# Altium schematic sheet KitArmM0.SchDoc
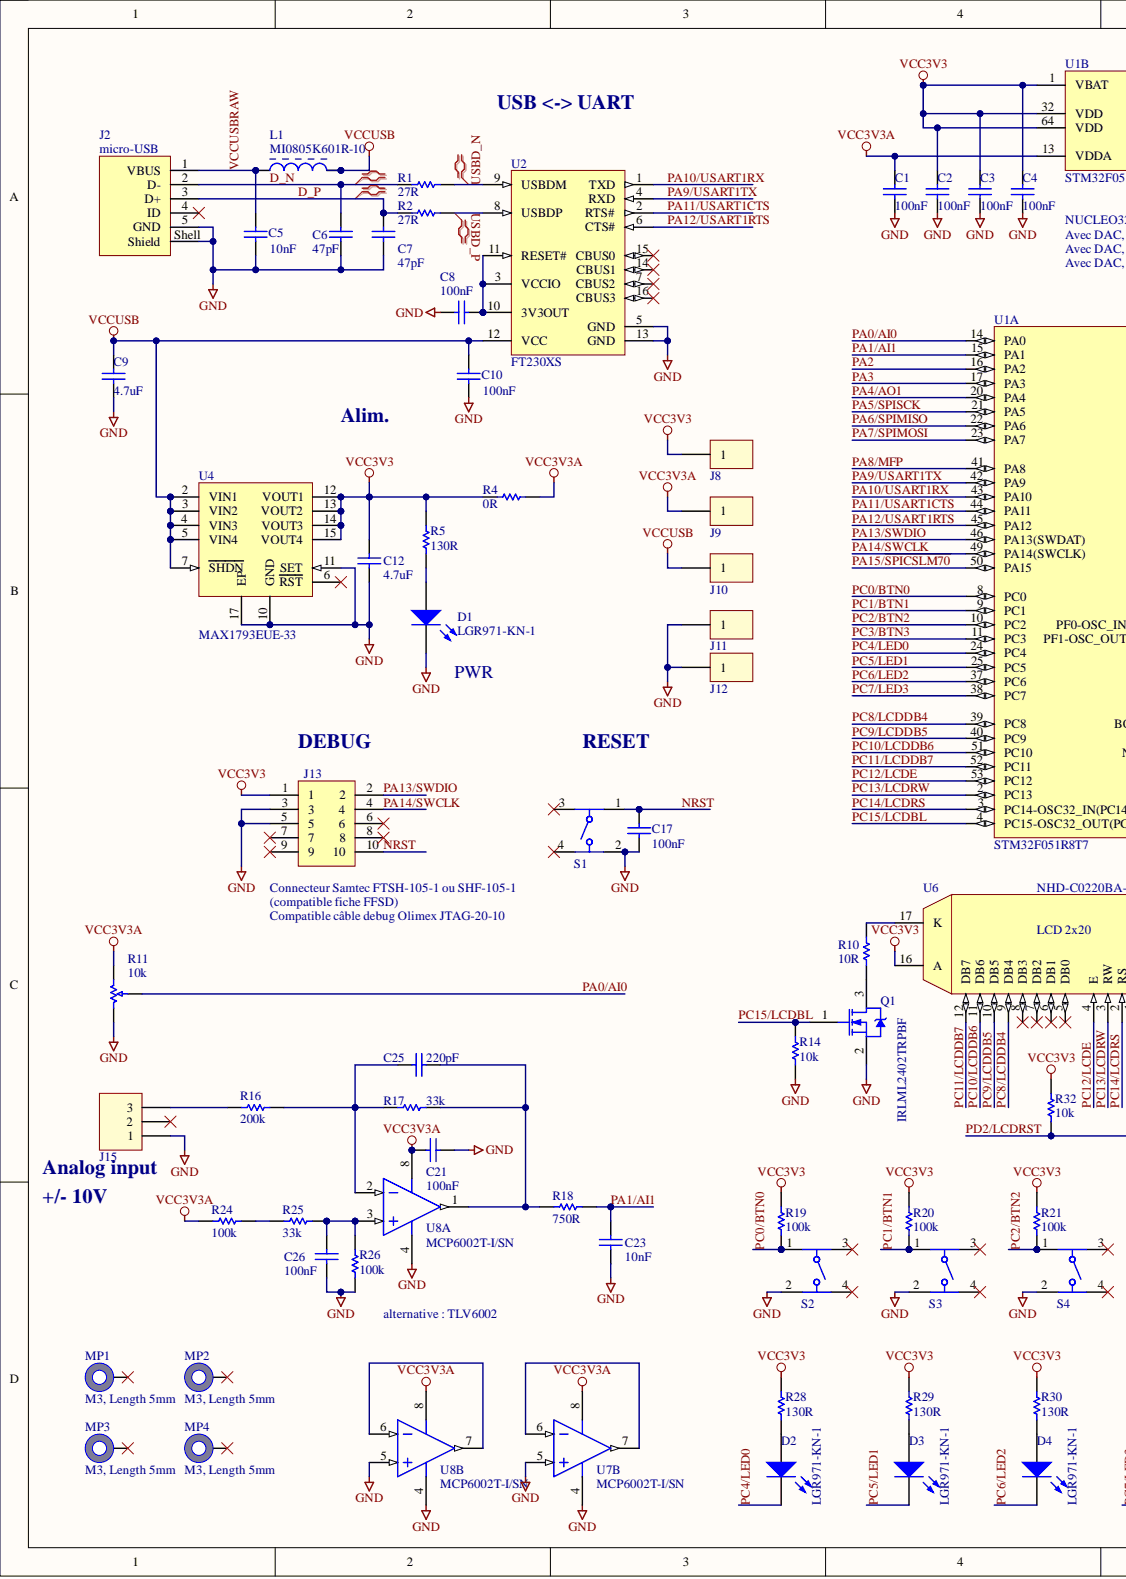
[diagram p0: KitArmM0.SchDoc, left]
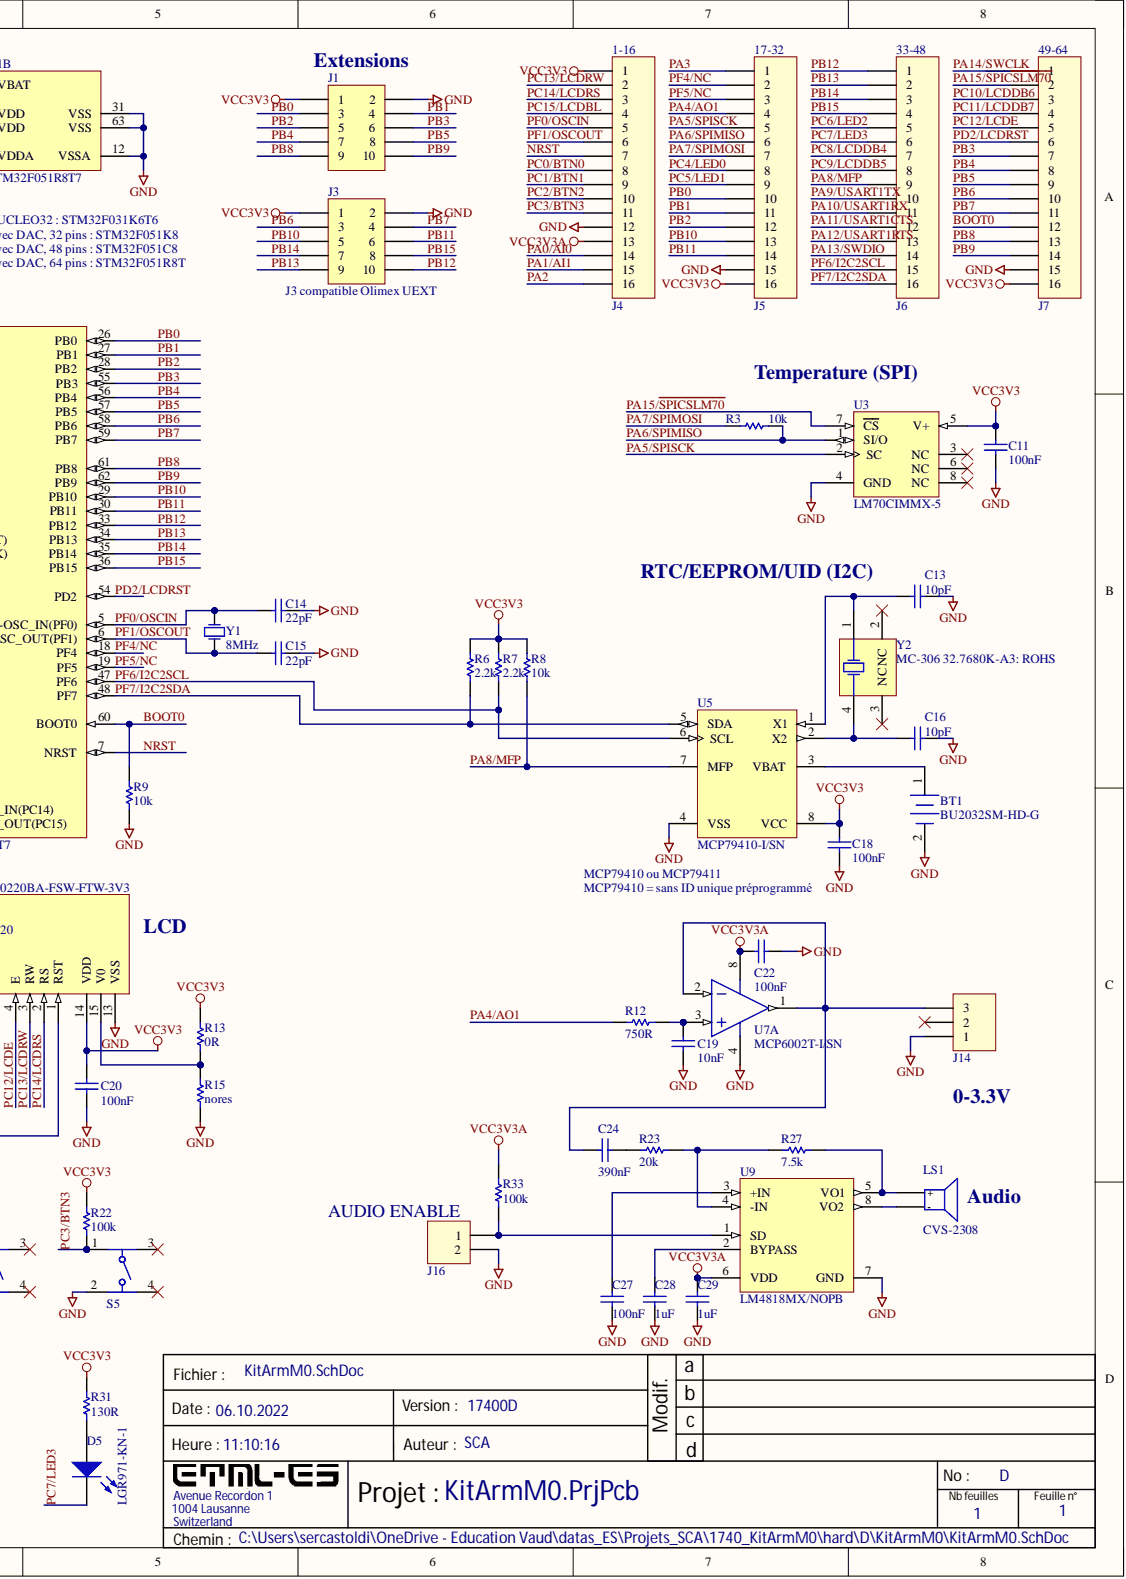
[diagram p1: KitArmM0.SchDoc, right]

## Parts
BT1  BU2032SM-HD-G  [BU2032SM-HD-G]
C1  value 100nF  [CAPC0805(2012)145_N]  (hidden comment: CAP 100nF 10V 0805(2012))
C2  value 100nF  [CAPC0805(2012)145_N]  (hidden comment: CAP 100nF 10V 0805(2012))
C3  value 100nF  [CAPC0805(2012)145_N]  (hidden comment: CAP 100nF 10V 0805(2012))
C4  value 100nF  [CAPC0805(2012)145_N]  (hidden comment: CAP 100nF 10V 0805(2012))
C5  value 10nF  [CAPC0805(2012)145_N]  (hidden comment: CAP 10nF 10V 0805(2012))
C6  value 47pF  [CAPC0805(2012)145_N]  (hidden comment: CAP 47pF 10V 0805(2012))
C7  value 47pF  [CAPC0805(2012)145_N]  (hidden comment: CAP 47pF 10V 0805(2012))
C8  value 100nF  [CAPC0805(2012)145_N]  (hidden comment: CAP 100nF 10V 0805(2012))
C9  value 4.7uF  [CAPC0805(2012)145_N]  (hidden comment: CAP 4.7uF 10V 0805(2012))
C10  value 100nF  [CAPC0805(2012)145_N]  (hidden comment: CAP 100nF 10V 0805(2012))
C11  value 100nF  [CAPC0805(2012)145_N]  (hidden comment: CAP 100nF 10V 0805(2012))
C12  value 4.7uF  [CAPC0805(2012)145_N]  (hidden comment: CAP 4.7uF 10V 0805(2012))
C13  value 10pF  [CAPC0805(2012)145_N]  (hidden comment: CAP 10pF 10V 0805(2012))
C14  value 22pF  [CAPC0805(2012)145_N]  (hidden comment: CAP 22pF 10V 0805(2012))
C15  value 22pF  [CAPC0805(2012)145_N]  (hidden comment: CAP 22pF 10V 0805(2012))
C16  value 10pF  [CAPC0805(2012)145_N]  (hidden comment: CAP 10pF 10V 0805(2012))
C17  value 100nF  [CAPC0805(2012)145_N]  (hidden comment: CAP 100nF 10V 0805(2012))
C18  value 100nF  [CAPC0805(2012)145_N]  (hidden comment: CAP 100nF 10V 0805(2012))
C19  value 10nF  [CAPC0805(2012)145_N]  (hidden comment: CAP 10nF 10V 0805(2012))
C20  value 100nF  [CAPC0805(2012)145_N]  (hidden comment: CAP 100nF 10V 0805(2012))
C21  value 100nF  [CAPC0805(2012)145_N]  (hidden comment: CAP 100nF 10V 0805(2012))
C22  value 100nF  [CAPC0805(2012)145_N]  (hidden comment: CAP 100nF 10V 0805(2012))
C23  value 10nF  [CAPC0805(2012)145_N]  (hidden comment: CAP 10nF 10V 0805(2012))
C24  value 390nF  [CAPC0805(2012)145_N]  (hidden comment: CAP 390nF 16V 0805(2012))
C25  value 220pF  [CAPC0805(2012)145_N]  (hidden comment: CAP 220pF 10V 0805(2012))
C26  value 100nF  [CAPC0805(2012)145_N]  (hidden comment: CAP 100nF 10V 0805(2012))
C27  value 100nF  [CAPC0805(2012)145_N]  (hidden comment: CAP 100nF 10V 0805(2012))
C28  value 1uF  [CAPC0805(2012)145_N]  (hidden comment: CAP 1uF 10V 0805(2012))
C29  value 1uF  [CAPC0805(2012)145_N]  (hidden comment: CAP 1uF 10V 0805(2012))
D1  LGR971-KN-1  [LED_0805]
D2  LGR971-KN-1  [LED_0805]
D3  LGR971-KN-1  [LED_0805]
D4  LGR971-KN-1  [LED_0805]
D5  LGR971-KN-1  [LED_0805]
J1  61201021621  [61201021621]  (value not drawn)
J2  value micro-USB  [629105150521]  (hidden comment: 629105150521)
J3  61201021621  [61201021621]  (value not drawn)
J4  61301611121  [61301611121]  (value not drawn)
J5  61301611121  [61301611121]  (value not drawn)
J6  61301611121  [61301611121]  (value not drawn)
J7  Rated Current: 3 A  [61301611121]  (hidden comment: 61301611121)
J8  61300111121  [61300111121]  (value not drawn)
J9  61300111121  [61300111121]  (value not drawn)
J10  61300111121  [61300111121]  (value not drawn)
J11  61300111121  [61300111121]  (value not drawn)
J12  61300111121  [61300111121]  (value not drawn)
J13  SHF-105-01-X-D-TH  [SHF-105-01-X-D-TH]  (value not drawn)
J14  61300311121  [61300311121]  (value not drawn)
J15  61300311121  [61300311121]  (value not drawn)
J16  61300211121  [61300211121]  (value not drawn)
L1  MI0805K601R-10  [LIRD-MI0805K601R-10-2_V]
LS1  CVS-2308  [CVS-2308]
MP1  M3, Length 5mm  [9774050360]
MP2  M3, Length 5mm  Length: 5mm  [9774050360]
MP3  M3, Length 5mm  [9774050360]
MP4  M3, Length 5mm  Length: 5mm  [9774050360]
Q1  IRLML2402TRPBF  [INTR-IRLML2402TRPBF-3_V]
R1  value 27R  [RESC0805(2012)_N]  (hidden comment: 27R 1% 0805(2012))
R2  value 27R  [RESC0805(2012)_N]  (hidden comment: 27R 1% 0805(2012))
R3  value 10k  [RESC0805(2012)_N]  (hidden comment: 10k 1% 0805(2012))
R4  value 0R  [RESC0805(2012)_N]  (hidden comment: 0R 1% 0805(2012))
R5  value 130R  [RESC0805(2012)_N]  (hidden comment: 130R 1% 0805(2012))
R6  value 2.2k  [RESC0805(2012)_N]  (hidden comment: 2.2k 1% 0805(2012))
R7  value 2.2k  [RESC0805(2012)_N]  (hidden comment: 2.2k 1% 0805(2012))
R8  value 10k  [RESC0805(2012)_N]  (hidden comment: 10k 1% 0805(2012))
R9  value 10k  [RESC0805(2012)_N]  (hidden comment: 10k 1% 0805(2012))
R10  value 10R  [RESC0805(2012)_N]  (hidden comment: 10R 1% 0805(2012))
R11  10k  [POT_PTV09A-2]
R12  value 750R  [RESC0805(2012)_N]  (hidden comment: 750R 1% 0805(2012))
R13  value 0R  [RESC0805(2012)_N]  (hidden comment: 0R 1% 0805(2012))
R14  value 10k  [RESC0805(2012)_N]  (hidden comment: 10k 1% 0805(2012))
R15  value nores  [RESC0805(2012)_N]  (hidden comment: nores 0805(2012))
R16  value 200k  [RESC0805(2012)_N]  (hidden comment: 200k 1% 0805(2012))
R17  value 33k  [RESC0805(2012)_N]  (hidden comment: 33k 1% 0805(2012))
R18  value 750R  [RESC0805(2012)_N]  (hidden comment: 750R 1% 0805(2012))
R19  value 100k  [RESC0805(2012)_N]  (hidden comment: 100k 1% 0805(2012))
R20  value 100k  [RESC0805(2012)_N]  (hidden comment: 100k 1% 0805(2012))
R21  value 100k  [RESC0805(2012)_N]  (hidden comment: 100k 1% 0805(2012))
R22  value 100k  [RESC0805(2012)_N]  (hidden comment: 100k 1% 0805(2012))
R23  value 20k  [RESC0805(2012)_N]  (hidden comment: 20k 1% 0805(2012))
R24  value 100k  [RESC0805(2012)_N]  (hidden comment: 100k 1% 0805(2012))
R25  value 33k  [RESC0805(2012)_N]  (hidden comment: 33k 1% 0805(2012))
R26  value 100k  [RESC0805(2012)_N]  (hidden comment: 100k 1% 0805(2012))
R27  value 7.5k  [RESC0805(2012)_N]  (hidden comment: 7.5k 1% 0805(2012))
R28  value 130R  [RESC0805(2012)_N]  (hidden comment: 130R 1% 0805(2012))
R29  value 130R  [RESC0805(2012)_N]  (hidden comment: 130R 1% 0805(2012))
R30  value 130R  [RESC0805(2012)_N]  (hidden comment: 130R 1% 0805(2012))
R31  value 130R  [RESC0805(2012)_N]  (hidden comment: 130R 1% 0805(2012))
R32  value 10k  [RESC0805(2012)_N]  (hidden comment: 10k 1% 0805(2012))
R33  value 100k  [RESC0805(2012)_N]  (hidden comment: 100k 1% 0805(2012))
S1  430182043816  [4301x20438x6_6x6x4.3]  (value not drawn)
S2  430182043816  [4301x20438x6_6x6x4.3]  (value not drawn)
S3  430182043816  [4301x20438x6_6x6x4.3]  (value not drawn)
S4  430182043816  [4301x20438x6_6x6x4.3]  (value not drawn)
S5  430182043816  [4301x20438x6_6x6x4.3]  (value not drawn)
U1  STM32F051R8T7  [STM-LQFP64_M]  (parts A,B)
U2  FT230XS  [FTDI-SSOP-16_L]
U3  LM70CIMMX-5  [MUA08A_L]
U4  MAX1793EUE-33  [TSOP65P640X110_HS-17N]
U5  MCP79410-I/SN  [SOIC-SN8_N]
U6  NHD-C0220BA-FSW-FTW-3V3  [NHD-C0220BA-FSW-FTW-3V3]
U7  MCP6002T-I/SN  [D0008A_M]  (parts A,B)
U8  MCP6002T-I/SN  [D0008A_M]  (parts A,B)
U9  LM4818MX/NOPB  [M08A_M]
Y1  Frequency: 8MHz  [HC-49/US-SM]  (hidden comment: ATS080BSM-1)
Y2  MC-306 32.7680K-A3: ROHS  Package Reference: MC-306  [EPSON-MC-306_V]

## Nets
GND: BT1.2 C1.1 C2.1 C3.1 C4.1 C5.1 C6.1 C7.1 C8.2 C9.1 C10.1 C11.1 C12.1 C13.1 C14.1 C15.1 C16.1 C17.1 C18.1 C19.2 C20.2 C21.1 C22.1 C23.2 C26.2 C27.2 C28.2 C29.2 D1.1 J1.2 J2.5(GND) J2.Shell(Shield) J3.2 J4.12 J5.15 J7.15 J11.1 J12.1 J13.3 J13.5 J14.1 J15.1 J16.2 Q1.2 R9.1 R11.1 R14.1 R15.1 R26.2 S1.2 S2.2 S3.2 S4.2 S5.2 U1.12(VSSA) U1.31(VSS) U1.63(VSS) U2.5(GND) U2.13(GND) U3.4(GND) U4.10(GND) U4.11(SET) U4.17(EP) U5.4(VSS) U6.13(VSS) U7.4 U7.5 U8.4 U8.5 U9.7(GND)
VCC3V3: C2.2 C3.2 C4.2 C11.2 C12.2 C18.2 C20.1 J1.1 J3.1 J4.1 J5.16 J7.16 J8.1 J13.1 R4.1 R5.2 R6.2 R7.2 R8.2 R13.2 R19.2 R20.2 R21.2 R22.2 R28.2 R29.2 R30.2 R31.2 R32.2 U1.1(VBAT) U1.32(VDD) U1.64(VDD) U3.5(V+) U4.12(VOUT1) U4.13(VOUT2) U4.14(VOUT3) U4.15(VOUT4) U5.8(VCC) U6.14(VDD) U6.16(A)
VCC3V3A: C1.2 C21.2 C22.2 C29.1 J4.13 J9.1 R4.2 R11.3 R24.2 R33.2 U1.13(VDDA) U7.8 U8.8 U9.6(VDD)
VCCUSB: C9.2 C10.2 J10.1 L1.2 U2.12(VCC) U4.2(VIN1) U4.3(VIN2) U4.4(VIN3) U4.5(VIN4) U4.7(SHDN)
BOOT0: J7.12 R9.2 U1.60(BOOT0)
D_N: C6.2 J2.2(D-) R1.1
D_P: C7.2 J2.3(D+) R2.1
NRST: C17.2 J4.7 J13.10 S1.1 U1.7(NRST)
PA0/AI0: J4.14 R11.2 U1.14(PA0)
PA1/AI1: C23.1 J4.15 R18.1 U1.15(PA1)
PA2: J4.16 U1.16(PA2)
PA3: J5.1 U1.17(PA3)
PA4/AO1: J5.4 R12.2 U1.20(PA4)
PA5/SPISCK: J5.5 U1.21(PA5) U3.2(SC)
PA6/SPIMISO: J5.6 R3.2 U1.22(PA6) U3.1(SI/O)
PA7/SPIMOSI: J5.7 R3.1 U1.23(PA7)
PA8/MFP: J6.9 R8.1 U1.41(PA8) U5.7(MFP)
PA9/USART1TX: J6.10 U1.42(PA9) U2.4(RXD)
PA10/USART1RX: J6.11 U1.43(PA10) U2.1(TXD)
PA11/USART1CTS: J6.12 U1.44(PA11) U2.2(RTS#)
PA12/USART1RTS: J6.13 U1.45(PA12) U2.6(CTS#)
PA13/SWDIO: J6.14 J13.2 U1.46(PA13(SWDAT))
PA14/SWCLK: J7.1 J13.4 U1.49(PA14(SWCLK))
PA15/~{SPICSLM70}: J7.2 U1.50(PA15) U3.7(CS)
PB0: J1.3 J5.10 U1.26(PB0)
PB1: J1.4 J5.11 U1.27(PB1)
PB2: J1.5 J5.12 U1.28(PB2)
PB3: J1.6 J7.7 U1.55(PB3)
PB4: J1.7 J7.8 U1.56(PB4)
PB5: J1.8 J7.9 U1.57(PB5)
PB6: J3.3 J7.10 U1.58(PB6)
PB7: J3.4 J7.11 U1.59(PB7)
PB8: J1.9 J7.13 U1.61(PB8)
PB9: J1.10 J7.14 U1.62(PB9)
PB10: J3.5 J5.13 U1.29(PB10)
PB11: J3.6 J5.14 U1.30(PB11)
PB12: J3.10 J6.1 U1.33(PB12)
PB13: J3.9 J6.2 U1.34(PB13)
PB14: J3.7 J6.3 U1.35(PB14)
PB15: J3.8 J6.4 U1.36(PB15)
PC0/BTN0: J4.8 R19.1 S2.1 U1.8(PC0)
PC1/BTN1: J4.9 R20.1 S3.1 U1.9(PC1)
PC2/BTN2: J4.10 R21.1 S4.1 U1.10(PC2)
PC3/BTN3: J4.11 R22.1 S5.1 U1.11(PC3)
PC4/LED0: D2.1 J5.8 U1.24(PC4)
PC5/LED1: D3.1 J5.9 U1.25(PC5)
PC6/LED2: D4.1 J6.5 U1.37(PC6)
PC7/LED3: D5.1 J6.6 U1.38(PC7)
PC8/LCDDB4: J6.7 U1.39(PC8) U6.9(DB4)
PC9/LCDDB5: J6.8 U1.40(PC9) U6.10(DB5)
PC10/LCDDB6: J7.3 U1.51(PC10) U6.11(DB6)
PC11/LCDDB7: J7.4 U1.52(PC11) U6.12(DB7)
PC12/LCDE: J7.5 U1.53(PC12) U6.4(E)
PC13/LCDRW: J4.2 U1.2(PC13) U6.3(RW)
PC14/LCDRS: J4.3 U1.3(PC14-OSC32_IN(PC14)) U6.2(RS)
PC15/LCDBL: J4.4 Q1.1 R14.2 U1.4(PC15-OSC32_OUT(PC15))
PD2/LCDRST: J7.6 R32.1 U1.54(PD2) U6.1(RST)
PF0/OSCIN: C14.2 J4.5 U1.5(PF0-OSC_IN(PF0)) Y1.2
PF1/OSCOUT: C15.2 J4.6 U1.6(PF1-OSC_OUT(PF1)) Y1.1
PF4/NC: J5.2 U1.18(PF4)
PF5/NC: J5.3 U1.19(PF5)
PF6/I2C2SCL: J6.15 R7.1 U1.47(PF6) U5.6(SCL)
PF7/I2C2SDA: J6.16 R6.1 U1.48(PF7) U5.5(SDA)
USBD_N: R1.2 U2.9(USBDM)
USBD_P: R2.2 U2.8(USBDP)
VCCUSBRAW: C5.2 J2.1(VBUS) L1.1
NetBT1_1: BT1.1 U5.3(VBAT)
NetC8_1: C8.1 U2.3(VCCIO) U2.10(3V3OUT) U2.11(RESET#)
NetC13_2: C13.2 U5.1(X1) Y2.1
NetC16_2: C16.2 U5.2(X2) Y2.4
NetC19_1: C19.1 R12.1 U7.3
NetC24_1: C24.1 R23.2
NetC24_2: C24.2 J14.3 U7.1 U7.2
NetC25_1: C25.1 R17.1 R18.2 U8.1
NetC25_2: C25.2 R16.1 R17.2 U8.2
NetC26_1: C26.1 R25.1 R26.1 U8.3
NetC27_1: C27.1 U9.3(+IN)
NetC28_1: C28.1 U9.2(BYPASS)
NetD1_2: D1.2 R5.1
NetD2_2: D2.2 R28.1
NetD3_2: D3.2 R29.1
NetD4_2: D4.2 R30.1
NetD5_2: D5.2 R31.1
NetJ15_3: J15.3 R16.2
NetJ16_1: J16.1 R33.1 U9.1(SD)
NetLS1_1: LS1.1 U9.8(VO2)
NetLS1_2: LS1.2 R27.1 U9.5(VO1)
NetQ1_3: Q1.3 R10.1
NetR10_2: R10.2 U6.17(K)
NetR13_1: R13.1 R15.2 U6.15(V0)
NetR23_1: R23.1 R27.2 U9.4(-IN)
NetR24_1: R24.1 R25.2
NetU7_6: U7.6 U7.7
NetU8_6: U8.6 U8.7

Not connected: J2 4(ID); J13 6 7 8 9; J14 2; J15 2; MP1 1; MP2 1; MP3 1; MP4 1; S1 3 4; S2 3 4; S3 3 4; S4 3 4; S5 3 4; U2 7(CBUS2) 14(CBUS1) 15(CBUS0) 16(CBUS3); U3 3(NC) 6(NC) 8(NC); U4 6(RST); U6 5(DB0) 6(DB1) 7(DB2) 8(DB3); Y2 2(NC) 3(NC)

## Library data of the parts
BT1 = BU2032SM-HD-G: CR2032 Battery holder; Component Kind: Standard; LatestRevisionDate: 17-Jul-2002; LatestRevisionNote: Re-released for DXP Platform.; Library Name: Miscellaneous Devices.IntLib; PackageDescription: Battery; 2 Leads; PackageReference: BAT-2; Published: 24-Mar-1999; Supplier: Digikey,Stock ES; Supplier min. qty: 1; Supplier Ref.: BU2032SM-HD-GCT-ND; Supplier unit price: 0.87; Value: BU2032SM-HD-G; Publisher: Altium Limited
C1-C4 C8 C10 C11 C17 C18 C20-C22 C26 C27 = CMP-1036-04924-1: CAP 100nF 10V ±20% 0805 (2012 Metric) Thickness 1.45mm SMD; Case-EIA: 0805; Case-Metric: 2012; Tolerance: Â±20%; Component Kind: Standard; Library Name: Altium Content Vault; Max Thickness: 1.45 mm; Rated Voltage: 10 V; Supplier: Stock ES; Technology: SMT
C5 C19 C23 = CMP-1036-04924-1: CAP 10nF 10V ±20% 0805 (2012 Metric) Thickness 1.45mm SMD; Case-EIA: 0805; Case-Metric: 2012; Tolerance: Â±20%; Component Kind: Standard; Library Name: Altium Content Vault; Max Thickness: 1.45 mm; Rated Voltage: 10 V; Supplier: Stock ES; Technology: SMT
C6 C7 = CMP-1036-04924-1: CAP 47pF 10V ±20% 0805 (2012 Metric) Thickness 1.45mm SMD; Case-EIA: 0805; Case-Metric: 2012; Tolerance: Â±20%; Component Kind: Standard; Library Name: Altium Content Vault; Max Thickness: 1.45 mm; Rated Voltage: 10 V; Supplier: Stock ES; Technology: SMT
C9 C12 = CMP-1036-04924-1: CAP 4.7uF 10V ±20% 0805 (2012 Metric) Thickness 1.45mm SMD; Case-EIA: 0805; Case-Metric: 2012; Tolerance: Â±20%; Component Kind: Standard; Library Name: Altium Content Vault; Max Thickness: 1.45 mm; Rated Voltage: 10 V; Supplier: Digikey,Stock ES; Supplier min. qty: 1; Supplier Ref.: 311-3426-1-ND; Supplier unit price: 0.12; Technology: SMT
C13 C16 = CMP-1036-04924-1: CAP 10pF 10V ±20% 0805 (2012 Metric) Thickness 1.45mm SMD; Case-EIA: 0805; Case-Metric: 2012; Tolerance: Â±20%; Component Kind: Standard; Library Name: Altium Content Vault; Max Thickness: 1.45 mm; Rated Voltage: 10 V; Supplier: Stock ES; Technology: SMT
C14 C15 = CMP-1036-04924-1: CAP 22pF 10V ±20% 0805 (2012 Metric) Thickness 1.45mm SMD; Case-EIA: 0805; Case-Metric: 2012; Tolerance: Â±20%; Component Kind: Standard; Library Name: Altium Content Vault; Max Thickness: 1.45 mm; Rated Voltage: 10 V; Supplier: Stock ES; Technology: SMT
C24 = CMP-1036-04924-1: CAP 390nF 16V ±10% 0805 (2012 Metric) Thickness 1.45mm SMD; Case-EIA: 0805; Case-Metric: 2012; Rated Voltage: 6.3V; Tolerance: Â±20%; Component Kind: Standard; Library Name: Altium Content Vault; Max Thickness: 1.45 mm; Supplier: Digikey; Supplier min. qty: 1; Supplier Ref.: 311-4290-1-ND; Supplier unit price: 0.24; Technology: SMT
C25 = CMP-1036-04924-1: CAP 220pF 16V ±10% 0805 (2012 Metric) Thickness 1.45mm SMD; Case-EIA: 0805; Case-Metric: 2012; Tolerance: Â±20%; Component Kind: Standard; Library Name: Altium Content Vault; Max Thickness: 1.45 mm; Rated Voltage: 10 V; Supplier: Stock ES; Technology: SMT
C28 C29 = CMP-1036-04924-1: CAP 1uF 10V ±20% 0805 (2012 Metric) Thickness 1.45mm SMD; Case-EIA: 0805; Case-Metric: 2012; Tolerance: Â±20%; Component Kind: Standard; Library Name: Altium Content Vault; Max Thickness: 1.45 mm; Rated Voltage: 10 V; Supplier: Stock ES; Technology: SMT
D1-D5 = LGR971-KN-1: SMD mono-color Chip LED, 0805, Green; Manufacturer: Wuerth Elektronik; Color: Green; Component Kind: Standard; ComponentLink1Description: Manufacturer URL; ComponentLink2Description: Datasheet; Library Name: KitArmM0.SchLib; PackageReference: 0805_A; PartNumber: LGR971-KN-1; Supplier: Stock ES; Value: LGR971-KN-1
J1 J3 = 61201021621: WR-BHD 2.54mm Male Box Header; Manufacturer: Wurth Elektronik; ComponentLink1 Description: Manufacturer; ComponentLink2 Description: Datasheet; NoPins: 10; Component Kind: Standard; ComponentLink1URL: http://www.we-online.com; ComponentLink2URL: http://katalog.we-online.de/em/datasheet/6120xx21621.pdf; Created Date: March 2016; partNo: 61201021621; Supplier: Digikey,Stock ES; Value: 61201021621; Created by: Baojun Chen
J2 = 629105150521: WR-Micro USB 2.0 Type B, Receptacle, Horizontal, SMT, with Pegs; Manufacturer: Wurth Elektronik; Interface Type: Type B; Match Code: WR-USB; Number of Ports: 1; Rated Current: 3A; Working Voltage: 30VAC; Application: Micro USB 2.0; Category: Input/Output Connectors; Component Kind: Standard; ComponentLink1Description: Website Link; ComponentLink1URL: https://www.we-online.com/redexpert/article/629105150521?ad; ComponentLink2Description: Datasheet Link; ComponentLink2URL: https://www.we-online.com/redexpert/spec/629105150521?ad; Gender: Receptacle; Library Name: KitArmM0.SCHLIB; Manufacturer Part Number: 629105150521; Mount: Surface Mount; Number of Pins: 5; Operating Temperature Max: 85°C; Operating Temperature Min: -40°C; Orientation: Horizontal; Packaging: Tape and Reel; Supplier: Digikey; Supplier min. qty: 1; Supplier Ref.: 732-5960-1-ND; Supplier unit price: 2.17
J4-J6 = 61301611121: WR-PHD 2.54 mm THT Pin Header, 16p; Pin Number: 16; Pitch: 2.54 mm; Rated Current: 3 A; Withstanding Voltage: 500 VAC; Working Voltage: 250 VAC; Component Kind: Standard; ComponentLink1Description: Manufacturer; ComponentLink1URL: http://www.we-online.de; ComponentLink2Description: Datasheet; ComponentLink2URL: http://katalog.we-online.de/em/datasheet/6130xx11121.pdf; Library Name: 613 0xx 111 21.SchLib; Part Number: 61301611121; Published Date: Aug 2017; Supplier: Stock ES; Value: 61301611121; Publisher: Wurth Elektronik
J7 = 61301611121: WR-PHD 2.54 mm THT Pin Header, 16p; Pin Number: 16; Pitch: 2.54 mm; Withstanding Voltage: 500 VAC; Working Voltage: 250 VAC; Component Kind: Standard; ComponentLink1Description: Manufacturer; ComponentLink1URL: http://www.we-online.de; ComponentLink2Description: Datasheet; ComponentLink2URL: http://katalog.we-online.de/em/datasheet/6130xx11121.pdf; Library Name: 613 0xx 111 21.SchLib; Part Number: 61301611121; Published Date: Aug 2017; Supplier: Stock ES; Value: 61301611121; Publisher: Wurth Elektronik
J8-J12 = 61300111121: WR-PHD 2.54 mm THT Pin Header, 1p; Pin Number: 1; Pitch: 2.54 mm; Rated Current: 3 A; Withstanding Voltage: 500 VAC; Working Voltage: 250 VAC; Component Kind: Standard; ComponentLink1Description: Manufacturer; ComponentLink1URL: http://www.we-online.de; ComponentLink2Description: Datasheet; ComponentLink2URL: http://katalog.we-online.de/em/datasheet/6130xx11121.pdf; Library Name: 613 0xx 111 21.SchLib; Part Number: 61300111121; Published Date: Aug 2017; Supplier: Stock ES; Value: 61300111121; Publisher: Wurth Elektronik
J13 = SHF-105-01-X-D-TH: 0.05"X0.05" SHROUDED TERMINAL STRIP.; MANUFACTURER: SAMTEC; Component Kind: Standard; Library Name: KitArmM0.SchLib; PCB DECAL: SHF-105-01-X-D-TH; Supplier: Digikey; Supplier min. qty: 1; Supplier Ref.: SAM10711-ND; Supplier unit price: 2.65; Value: SHF-105-01-X-D-TH; CREATED: June 16, 2016 - Wendy Xin
J14 J15 = 61300311121: WR-PHD 2.54 mm THT Pin Header, 3p; Pin Number: 3; Pitch: 2.54 mm; Rated Current: 3 A; Withstanding Voltage: 500 VAC; Working Voltage: 250 VAC; Component Kind: Standard; ComponentLink1Description: Manufacturer; ComponentLink1URL: http://www.we-online.de; ComponentLink2Description: Datasheet; ComponentLink2URL: http://katalog.we-online.de/em/datasheet/6130xx11121.pdf; Library Name: KitArmM0.SCHLIB; Part Number: 61300311121; Published Date: Aug 2017; Supplier: Stock ES; Value: 61300311121; Publisher: Wurth Elektronik
J16 = 61300211121: WR-PHD 2.54 mm THT Pin Header, 2p; Pin Number: 2; Pitch: 2.54 mm; Rated Current: 3 A; Withstanding Voltage: 500 VAC; Working Voltage: 250 VAC; Component Kind: Standard; ComponentLink1Description: Manufacturer; ComponentLink1URL: http://www.we-online.de; ComponentLink2Description: Datasheet; ComponentLink2URL: http://katalog.we-online.de/em/datasheet/6130xx11121.pdf; Library Name: 613 0xx 111 21.SchLib; Part Number: 61300211121; Published Date: Aug 2017; Supplier: Stock ES; Value: 61300211121; Publisher: Wurth Elektronik
L1 = CMP-2000-05196-1: Chip Bead for Power Filtering, 1500 mA, 600 Ohm, -40 to 125 degC, 0805 (2012 Metric), RoHS, Tape and Reel; Manufacturer: Laird; Catalog Drawings: (HI,HZ,LI,MI)0805Series_1.10mmMax.Height; Current Rating (Max): 1.5A; DC Resistance (DCR) (Max): 100mOhm; Filter Type: PowerLine; Impedance @ Frequency: 600Ohm@100MHz; Kits: 240-2227-ND-KITEMIFERRITEBEADCHIPSMD; Number of Lines: 1; Online Catalog: MISeries; Other Names: 240-2390-1; Category: Filters; Component Kind: Standard; Datasheet URL: https://datasheet.ciiva.com/3082/mi0805k601r-10-a-vp-3082219.pdf; Datasheet Version: Rev. A, 01/2004; Family: FerriteBeadsandChips; Height (Max): 0.043"(1.10mm); Library Name: Altium Content Vault; Manufacturer URL: https://www.lairdtech.com; Mounting Type: SurfaceMount; Operating Temperature: -40degC~125degC; Package / Case: 0805(2012Metric); Package Description: 2-Pin Surface Mount Device, Body 2 x 1.25 mm; Package Reference: MI0805K601R-10-2; Packaging: CutTape(CT); Ratings: -; Series: MI; Size / Dimension: 0.079"Lx0.049"W(2.00mmx1.25mm); Standard Package: 1; Supplier: Digikey; Supplier min. qty: 1; Supplier Ref.: 240-2390-1-ND; Supplier unit price: 0.11; Value: MI0805K601R-10
LS1 = CVS-2308: Loudspeaker THT CVS-2308; Component Kind: Standard; LatestRevisionDate: 17-Jul-2002; LatestRevisionNote: Re-released for DXP Platform.; Library Name: KitArmM0.SchLib; PackageReference: CVS-2308; Published: 24-Mar-1999; Supplier: Digikey; Supplier min. qty: 1; Supplier Ref.: 102-2500-ND; Supplier unit price: 2.80; Value: CVS-2308; Publisher: Altium Limited
MP1-MP4 = 9774050360: WA-SMSI SMT Steel Spacer, M3 Thread Internal, Length 5mm; InnerDiameter: 4.2mm; OuterDiameter: 6mm; Component Kind: Standard; ComponentLink1Description: Manufacturer; ComponentLink1URL: http://www.we-online.de; ComponentLink2Description: Datasheet; ComponentLink2URL: http://katalog.we-online.de/em/datasheet/9774050360.pdf; Packaging: Bulk; PartNumber: 9774050360; PublishedDate: Dec. 2016; Supplier: Stock ES; Thread: M3; Value: M3, Length 5mm; Publisher: Wurth Elektronik
  MP1 MP3: Length: 5mm
Q1 = CMP-2000-05155-1: HEXFET(R) Power MOSFET, N-Channel, 20 V, 1.2 A, 3-Pin SOT-23, RoHS, Tape and Reel; Manufacturer: International Rectifier; Continuous Drain Current Id: 1.2A; Current Temperature: 25degC; Drain Source Voltage Vds: 20V; External Depth: 2.5mm; External Length / Height: 1.12mm; External Width: 3.05mm; Junction Temperature Tj Min: -55degC; No. of Pins: 3; No. of Transistors: 1; On Resistance Rds(on): 250mohm; Power Dissipation Pd: 540mW; Pulse Current Idm: 7.4A; Rds(on) Test Voltage Vgs: 4.5V; Reel Quantity: 3000; SMD Marking: 1A; SVHC: NoSVHC(19-Dec-2012); Threshold Voltage Vgs Typ: 700mV; Transistor Polarity: NChannel; Component Kind: Standard; Current Id Max: 1.2A; Datasheet URL: https://datasheet.ciiva.com/29326/162826-da-01-en-irlml-2402tr-29326791.pdf; Datasheet Version: Jan-03; Full Power Rating Temperature: 25degC; Library Name: Altium Content Vault; Manufacturer URL: http://internationalrectifier.com/; Operating Temperature Range: -55degCto+150degC; Package / Case: SOT-23; Supplier: Digikey,Stock ES; Supplier min. qty: 1; Supplier Ref.: IRLML2402PBFCT-ND; Supplier unit price: 0.54; Tape Width: 8mm; Termination Type: SMD; Transistor Case Style: SOT-23; Value: IRLML2402TRPBF; Voltage Vds Typ: 20V; Voltage Vgs Max: 700mV; Voltage Vgs Rds on Measurement: 4.5V; Voltage Vgs th Min: 700mV
R1 R2 = CMP-1013-00332-1: 27R 0.125W 1% 0805 (2012 Metric)  SMD; Case-EIA: 0805; Case-Metric: 2012; Component Kind: Standard; Library Name: Altium Content Vault; Power: 0.125 W; Supplier: Stock ES; Technology: SMT; Tolerance: 1 %
R3 R8 R9 R14 R32 = CMP-1013-00332-1: 10k 0.125W 1% 0805 (2012 Metric)  SMD; Case-EIA: 0805; Case-Metric: 2012; Component Kind: Standard; Library Name: Altium Content Vault; Power: 0.125 W; Supplier: Stock ES; Technology: SMT; Tolerance: 1 %
R4 R13 = CMP-1013-00332-1: 0R 0.125W 1% 0805 (2012 Metric)  SMD; Case-EIA: 0805; Case-Metric: 2012; Component Kind: Standard; Library Name: Altium Content Vault; Power: 0.125 W; Supplier: Stock ES; Technology: SMT; Tolerance: 1 %
R5 R28-R31 = CMP-1013-00332-1: 130R 0.125W 1% 0805 (2012 Metric)  SMD; Case-EIA: 0805; Case-Metric: 2012; Component Kind: Standard; Library Name: Altium Content Vault; Power: 0.125 W; Supplier: Stock ES; Technology: SMT; Tolerance: 1 %
R6 R7 = CMP-1013-00332-1: 2.2k 0.125W 1% 0805 (2012 Metric)  SMD; Case-EIA: 0805; Case-Metric: 2012; Component Kind: Standard; Library Name: Altium Content Vault; Power: 0.125 W; Supplier: Stock ES; Technology: SMT; Tolerance: 1 %
R10 = CMP-1013-00332-1: 10R 0.125W 1% 0805 (2012 Metric)  SMD; Case-EIA: 0805; Case-Metric: 2012; Component Kind: Standard; Library Name: Altium Content Vault; Power: 0.125 W; Supplier: Stock ES; Technology: SMT; Tolerance: 1 %
R11 = PTV09A-2020F-B503: Bourns potentiometer PTV09A-2020F-B503; Manufacturer_Name: Bourns Electronics; Manufacturer_Part_Number: PTV09A-2020F-B103, PTV09A-2020F-B503 (50k); C: Copyright (C) 2015 Accelerated Designs. All rights reserved; Current_Rating: Unknown; HEADER_GROUP: Resistors; HEADER_SECTION: Passives; Tolerance: 20%; Component Kind: Standard; Library Name: KitArmM0.SCHLIB; Mounting: Bracket Mount; Package/Case: Unknown; Supplier: Digikey; Supplier min. qty: 1; Supplier Ref.: PTV09A-2020F-B103-ND, PTV09A-2020F-B503-ND (50k); Supplier unit price: 0.94; Value: 10k; Vendor: Bourns Electronics; Voltage: Unknown; Copyright: Copyright (C) 2015 Accelerated Designs. All rights reserved
R12 R18 = CMP-1013-00332-1: 750R 0.125W 1% 0805 (2012 Metric)  SMD; Case-EIA: 0805; Case-Metric: 2012; Component Kind: Standard; Library Name: Altium Content Vault; Power: 0.125 W; Supplier: Stock ES; Technology: SMT; Tolerance: 1 %
R15 = CMP-1013-00332-1: nores 0805 (2012 Metric)  SMD; Case-EIA: 0805; Case-Metric: 2012; Power: 0.125 W; Tolerance: 1 %; Component Kind: Standard; Library Name: Altium Content Vault; Supplier: Stock ES; Technology: SMT
R16 = CMP-1013-00332-1: 200k 0.125W 1% 0805 (2012 Metric)  SMD; Case-EIA: 0805; Case-Metric: 2012; Component Kind: Standard; Library Name: Altium Content Vault; Power: 0.125 W; Supplier: Stock ES; Technology: SMT; Tolerance: 1 %
R17 R25 = CMP-1013-00332-1: 33k 0.125W 1% 0805 (2012 Metric)  SMD; Case-EIA: 0805; Case-Metric: 2012; Component Kind: Standard; Library Name: Altium Content Vault; Power: 0.125 W; Supplier: Stock ES; Technology: SMT; Tolerance: 1 %
R19-R22 R24 R26 R33 = CMP-1013-00332-1: 100k 0.125W 1% 0805 (2012 Metric)  SMD; Case-EIA: 0805; Case-Metric: 2012; Component Kind: Standard; Library Name: Altium Content Vault; Power: 0.125 W; Supplier: Stock ES; Technology: SMT; Tolerance: 1 %
R23 = CMP-1013-00332-1: 20k 0.125W 1% 0805 (2012 Metric)  SMD; Case-EIA: 0805; Case-Metric: 2012; Component Kind: Standard; Library Name: Altium Content Vault; Power: 0.125 W; Supplier: Stock ES; Technology: SMT; Tolerance: 1 %
R27 = CMP-1013-00332-1: 7.5k 0.125W 1% 0805 (2012 Metric)  SMD; Case-EIA: 0805; Case-Metric: 2012; Component Kind: Standard; Library Name: Altium Content Vault; Power: 0.125 W; Supplier: Stock ES; Technology: SMT; Tolerance: 1 %
S1-S5 = 430182043816: WS-TASV 6x6mm Tact Switch, SMD version; Operation Force: 160g; Component Kind: Standard; ComponentLink1Description: Manufacturer; ComponentLink1URL: http://www.we-online.de; ComponentLink2Description: Datasheet; ComponentLink2URL: http://katalog.we-online.de/em/datasheet/4301x2xxx8x6.pdf; Library Name: KitArmM0.SCHLIB; Life Cycle: 1000000 cycles; Part Number: 430182043816; Published Date: June 2017; Size: 6x6x4.3 mm; Supplier: Stock ES; Value: 430182043816; Publisher: Wurth Elektronik
U1 = CMP-0304-00007-3: ARM Cortex-M0 32-bit MCU, 64 KB Flash, 8 KB Internal RAM, 55 I/Os, 64-pin LQFP, -40 to 105 degC, Tray; FLASH Size (Prog) (kB): 64; I/Os (High Current): 55; Internal RAM Size (kB): 8; Operating Frequency(F) (Processor speed) (MHz): 48; Supply Voltage(Vcc) min (V): 2; Component Kind: Standard; ComponentLink1Description: Manufacturer URL; ComponentLink1URL: http://www.st.com/web/en/home.html; ComponentLink2Description: Datasheet; ComponentLink2URL: http://www.st.com/st-web-ui/static/active/en/resource/technical/document/datasheet/DM00039193.pdf; ComponentLink3Description: Package Specification; ComponentLink3URL: http://www.st.com/st-web-ui/static/active/en/resource/technical/document/datasheet/DM00037051.pdf; Core: ARM Cortex-M0; DatasheetVersion: Rev. 3; Library Name: Altium Content Vault; Mounting Technology: Surface Mount; PackageDescription: 64-Pin Low Profile Quad Flat Package, 10 x 10 mm Body, 0.5 mm Pitch; PackageReference: LQFP64; PackageVersion: Rev. 4, 06/2013; Packing: Tray; PartNumber: STM32F051R8T7; Supplier: Digikey; Supplier min. qty: 1; Supplier Ref.: 497-13628-1-ND; Supplier unit price: 3.37; Value: STM32F051R8T7
U2 = CMP-2000-06288-1: USB to Basic UART IC, 2.97 to 5.5 V, -40 to 85 degC, 16-Pin SSOP, RoHS; Manufacturer: FTDI; Data Rate: 3Mbaud; No. of Pins: 16Pins; Supply Voltage Max: 5.5V; Supply Voltage Min: 2.97V; SVHC: NoSVHC(17-Dec-2015); USB Standard: USB2.0; USB Type: Transceiver; Component Kind: Standard; Datasheet URL: http://www.ftdichip.com/Support/Documents/DataSheets/ICs/DS_FT230X.pdf; Datasheet Version: Rev. 1.4, 05/2016; Interface Case Style: SSOP; Library Name: Altium Content Vault; Manufacturer URL: http://www.ftdichip.com/; Mounting Technology: SurfaceMount; MSL: MSL3-168hours; No. of Ports: -; Operating Temperature Max: 85°C; Operating Temperature Min: -40°C; Package Description: 16-Pin Shrink Small Outline Package Integrated Circuit, Body 4.9 x 3.9 mm, Pitch 0.635 mm; Package Reference: SSOP-16; Packaging: CutTape; Product Range: -; Supplier: Digikey; Supplier min. qty: 1; Supplier Ref.: 768-1135-1-ND; Supplier unit price: 2.00; Value: FT230XS
U3 = CMP-0066-00304-2: SPI/10-Bit plus Sign Digital Temperature Sensor, 8-pin Mini SOIC; Manufacturer: National Semiconductor; CompType: Temp Sensor IC; PackageTopMarking: ZXTT*T03C; PowerWise: Y; Code_JEDEC: MO-187-AA; Component Kind: Standard; ComponentLink1Description: LM70 Datasheet; ComponentLink1URL: http://www.national.com/ds/LM/LM70.pdf; ComponentLink2Description: LM70 Web Page; ComponentLink2URL: http://www.national.com/pf/LM/LM70.html; ComponentLink3Description: MUA08A Package Page; ComponentLink3URL: http://www.national.com/packaging/folders/mua08a.html; DatasheetVersion: Feb-2010; Digikey ID: LM70CIMMX-3/NOPBCT-ND; Library Name: Altium Content Vault; PackageDescription: MINI SOIC, .118 x .118 x .034in, 8 Lead, .0256in Pitch; PackageReference: MUA08A; PackageVersion: revF, Apr-2008; PinCount: 8; RevisionNote: Component URLs added; RoHS: N; Supplier: Digikey,Stock ES; Supplier min. qty: 1; Supplier Ref.: 296-38145-1-ND; Supplier unit price: 2.81; Value: LM70CIMMX-5
U4 = MAX1793EUE-33: MAX1793 linear regulator 3.3V; Code_JEDEC: MO-178-AB; Component Kind: Standard; ComponentLink1Description: Manufacturer URL; ComponentLink1URL: http://www.maxim-ic.com; ComponentLink2Description: Datasheet; ComponentLink2URL: https://datasheets.maximintegrated.com/en/ds/MAX1793.pdf; ComponentLink3Description: Package Specification; Library Name: KitArmM0.SchLib; PackageDescription: TSSOP16+exposed pad; PackageReference: http://pdfserv.maxim-ic.com/package_dwgs/21-0108.PDF; Supplier: Digikey,Stock ES; Supplier min. qty: 1; Supplier Ref.: MAX1793EUE33+-ND; Supplier unit price: 3.88; Value: MAX1793EUE-33
U5 = CMP-0186-00231-1: I2C Real-Time Clock/Calendar with EEPROM, SRAM and Battery Switchover, 8-Pin SOIC, Industrial Temperature; Code_IPC: SOIC127P600-8; Code_JEDEC: MS-012; Component Kind: Standard; ComponentLink1Description: Manufacturer URL; ComponentLink1URL: http://www.microchip.com/; ComponentLink2Description: Datasheet; ComponentLink2URL: http://ww1.microchip.com/downloads/en/DeviceDoc/22266D.pdf; ComponentLink3Description: Package Specification; ComponentLink3URL: http://www.microchip.com/stellent/groups/techpub_sg/documents/packagingspec/en012702.pdf; DatasheetVersion: revD, Dec-2011; Library Name: Altium Content Vault; PackageDescription: 8-Lead Plastic Small Outline (SN) - Narrow, 3.90mm Body [SOIC]; PackageReference: SOIC-SN8; PackageVersion: revBB, Aug-2009; Supplier: Mouser, Digikey; Supplier min. qty: 1; Supplier Ref.: 579-MCP79410-I/SN, MCP79411-I/SN-ND; Supplier unit price: 1.04; Value: MCP79410-I/SN
U6 = NHD-C0220BA-FSW-FTW-3V3: LCD Alphanumeric 2x20; Component Kind: Standard; Library Name: KitArmM0.SCHLIB; Supplier: Newhaven Display; Supplier min. qty: 1; Supplier Ref.: NHD-C0220BA-FSW-FTW-3V3; Supplier unit price: 13.87; Value: NHD-C0220BA-FSW-FTW-3V3
U7 U8 = MCP6002: 1 MHz, Low-Power Op Amp, Rail-to-Rail Operational Amplifier, 1.8 to 6.0 V, -40 to 85 degC, 8-pin SOIC (D8), RoHS; Manufacturer: Microchip; GBW(Typ)(MHz): 1; Number of Channels: 2; Rating: Catalog; Slew Rate(Typ)(V/us): 0.6; Total Supply Voltage(Max)(+5V is 5, +/-5V is 10): 6.0; Vio (25C)(Max)(mV): 6; Code_JEDEC: MS-012; Component Kind: Standard; ComponentLink1Description: Manufacturer URL; ComponentLink2Description: Datasheet; ComponentLink3Description: Package Specification; Eco-Plan: RoHS; Library Name: KitArmM0.SCHLIB; Mounting Technology: Surface Mount; PackageDescription: 8-Pin Plastic Small Outline, Body 4.9 x 3.9 mm, Pitch 1.27 mm; PartNumber: MCP6002T-I/SN; Supplier: Digikey; Supplier min. qty: 1; Supplier Ref.: MCP6002T-I/SNCT-ND; Supplier unit price: 0.41; Value: MCP6002T-I/SN
U9 = CMP-0057-00389-2: 350mW Audio Power Amplifier with Shutdown Mode, 8-pin Narrow SOIC; Manufacturer: National Semiconductor; CompType: Audio IC; PackageTopMarking: NSZXTT*LM48*18M; PowerWise: N; Code_IPC: SOIC127P600-8; Code_JEDEC: MS-012-AA; Component Kind: Standard; ComponentLink1Description: LM4818 Datasheet; ComponentLink1URL: http://www.national.com/ds/LM/LM4818.pdf; ComponentLink2Description: LM4818 Web Page; ComponentLink2URL: http://www.national.com/pf/LM/LM4818.html; ComponentLink3Description: M08A Package Page; ComponentLink3URL: http://www.national.com/packaging/folders/m08a.html; DatasheetVersion: Apr-2002; Library Name: Altium Content Vault; PackageDescription: SOIC Narrow, 4.9 x 3.9 x 1.45mm, 8 Lead, 1.27mm Pitch; PackageReference: M08A; PackageVersion: revM, May-2009; PinCount: 8; RevisionNote: Component URLs added; RoHS: N; Supplier: Digikey; Supplier min. qty: 1; Supplier Ref.: LM4818MX/NOPBCT-ND; Supplier unit price: 0.93; Value: LM4818MX/NOPB
Y1 = XTAL-ATS080BSM-1: 8 MHz; Manufacturer: CTS-Frequency Controls; ESR (Equivalent Series Resistance): 60Ohm; Frequency Stability: 50ppm; Frequency Tolerance: 30ppm; Load Capacitance: 18pF; Case/Package: SMD/SMT; Component Kind: Standard; Lead Free: LeadFree; Library Name: KitArmM0.SCHLIB; Max Operating Temperature: 70degC; Min Operating Temperature: -20degC; Mount: SurfaceMount; Number of Pins: 2; Package Description: 2-Pin Surface Mount Device, Body 5 x 11.7 mm; Package Quantity: 1000; Package Reference: HC49/US-SM; Packaging: TapeandReel; Radiation Hardening: No; REACH SVHC: NoSVHC; RoHSCompliant: True; Series Resistance: 60Ohm; Supplier: Digikey,Stock ES; Supplier min. qty: 1; Supplier Ref.: CTX884CT-ND; Supplier unit price: 0.39; Termination: SMD/SMT; Tolerance: 30ppm; Value: ATS080BSM-1
Y2 = MC-306 32.7680K-A0: Crystal, 32.768 kHz, 12.5 pF, 50 KOhm, -40 to 85 degC, 4-Pin SMD, RoHS, Tape and Reel; Manufacturer: Epson; Catalog Drawings: MC-306SeriesSide  MC-306SeriesTop  MC-306SeriesCircuit; Dynamic Catalog: MC-306,MC-405andMC-406Series; ESR (Equivalent Series Resistance): 50kOhm; Frequency Tolerance: ±20ppm; Load Capacitance: 12.5pF; Operating Mode: Fundamental; Product Training Modules: Crystals; Category: CrystalsandOscillators; Component Kind: Standard; Datasheet URL: https://support.epson.biz/td/api/doc_check.php?dl=brief_MC-306_en.pdf; Datasheet Version: 01/2014; Family: Crystals; Frequency: 32.768kHz; Manufacturer URL: https://www.eea.epson.com/; Mounting Technology: SurfaceMount; Mounting Type: SurfaceMount; Operating Temperature: -40°C~85°C; Package / Case: 4-SOJ,5.50mmpitch; Package Description: 4-Pin Surface Mount Device, Body 8 x 3.2 mm; Packaging: Tape&Reel(TR); Series: MC-306; Standard Package: 1,000; Supplier: Digikey; Supplier min. qty: 1; Supplier Ref.: SER4306CT-ND; Supplier unit price: 1.61; Type: kHzCrystal(TuningFork); Value: MC-306 32.7680K-A3: ROHS
